# Altium schematic sheet Proot test board.SchDoc
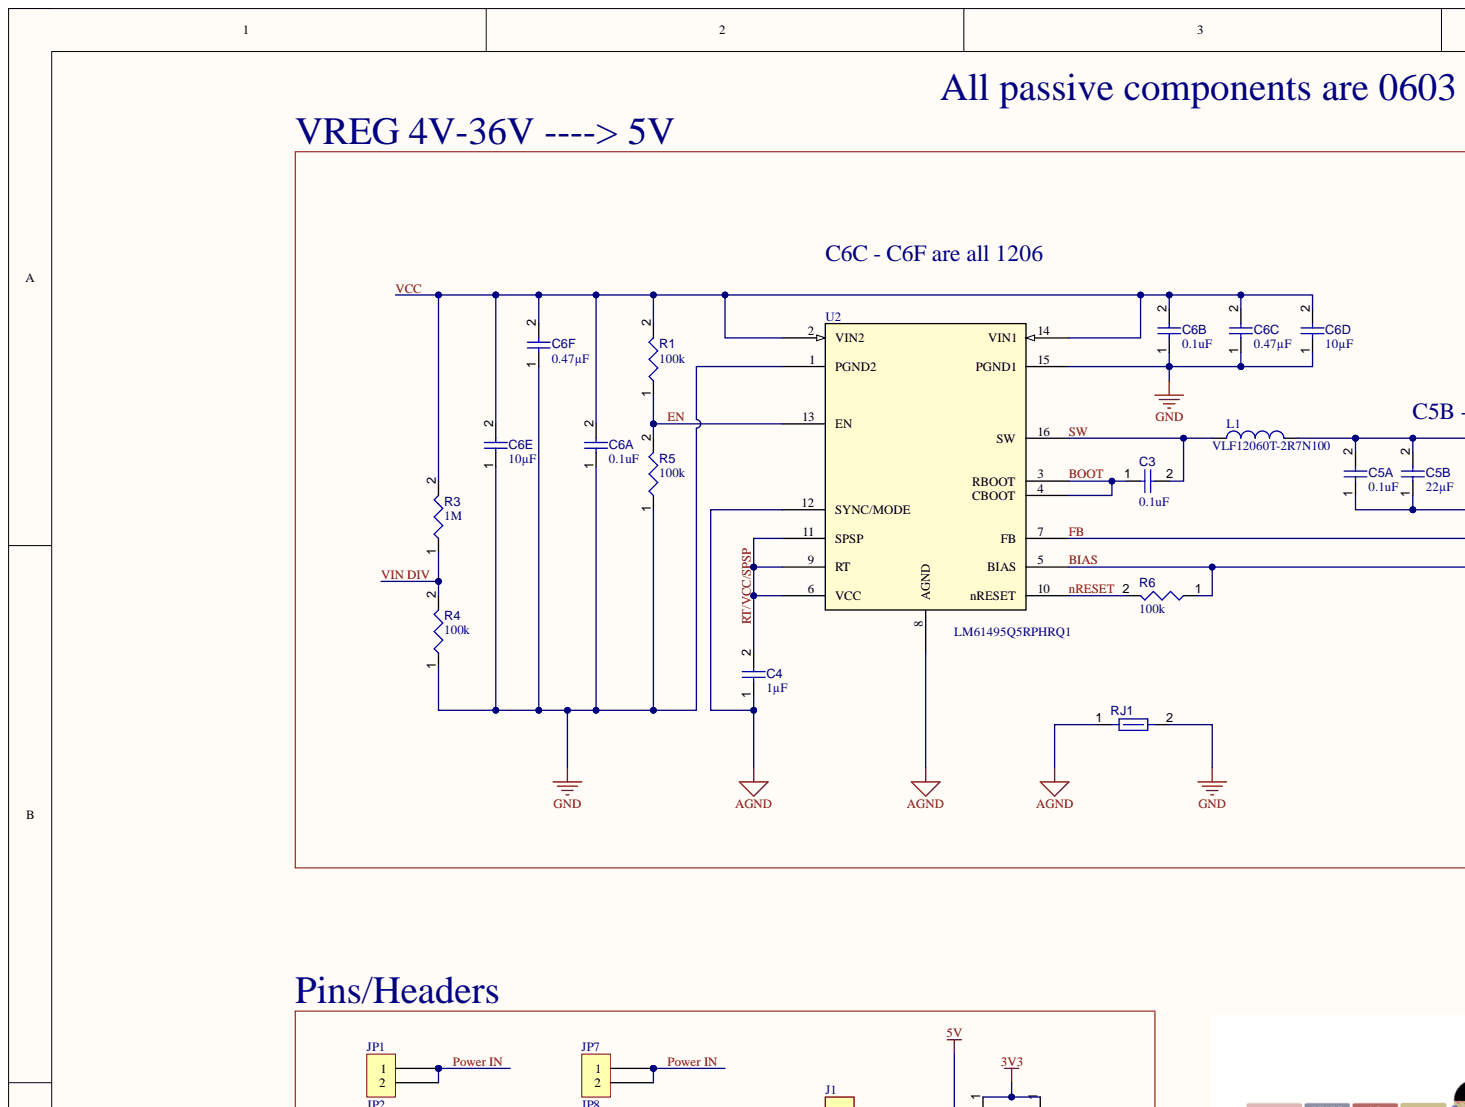
[diagram p0: Proot test board.SchDoc, top left]
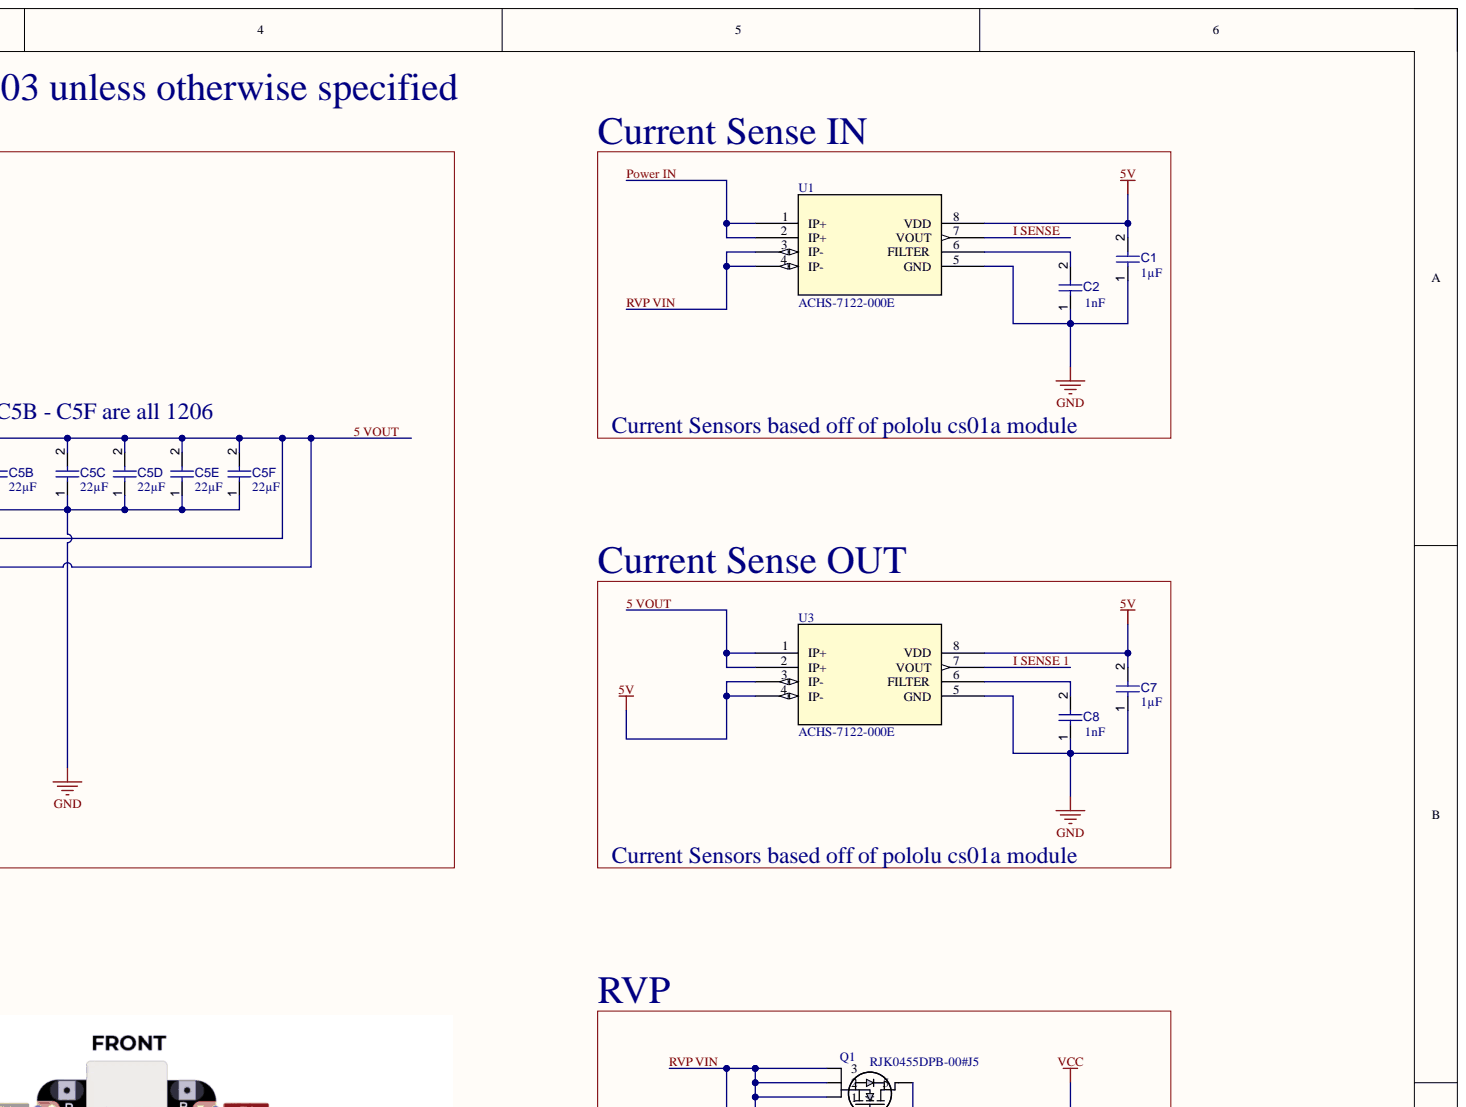
[diagram p1: Proot test board.SchDoc, top right]
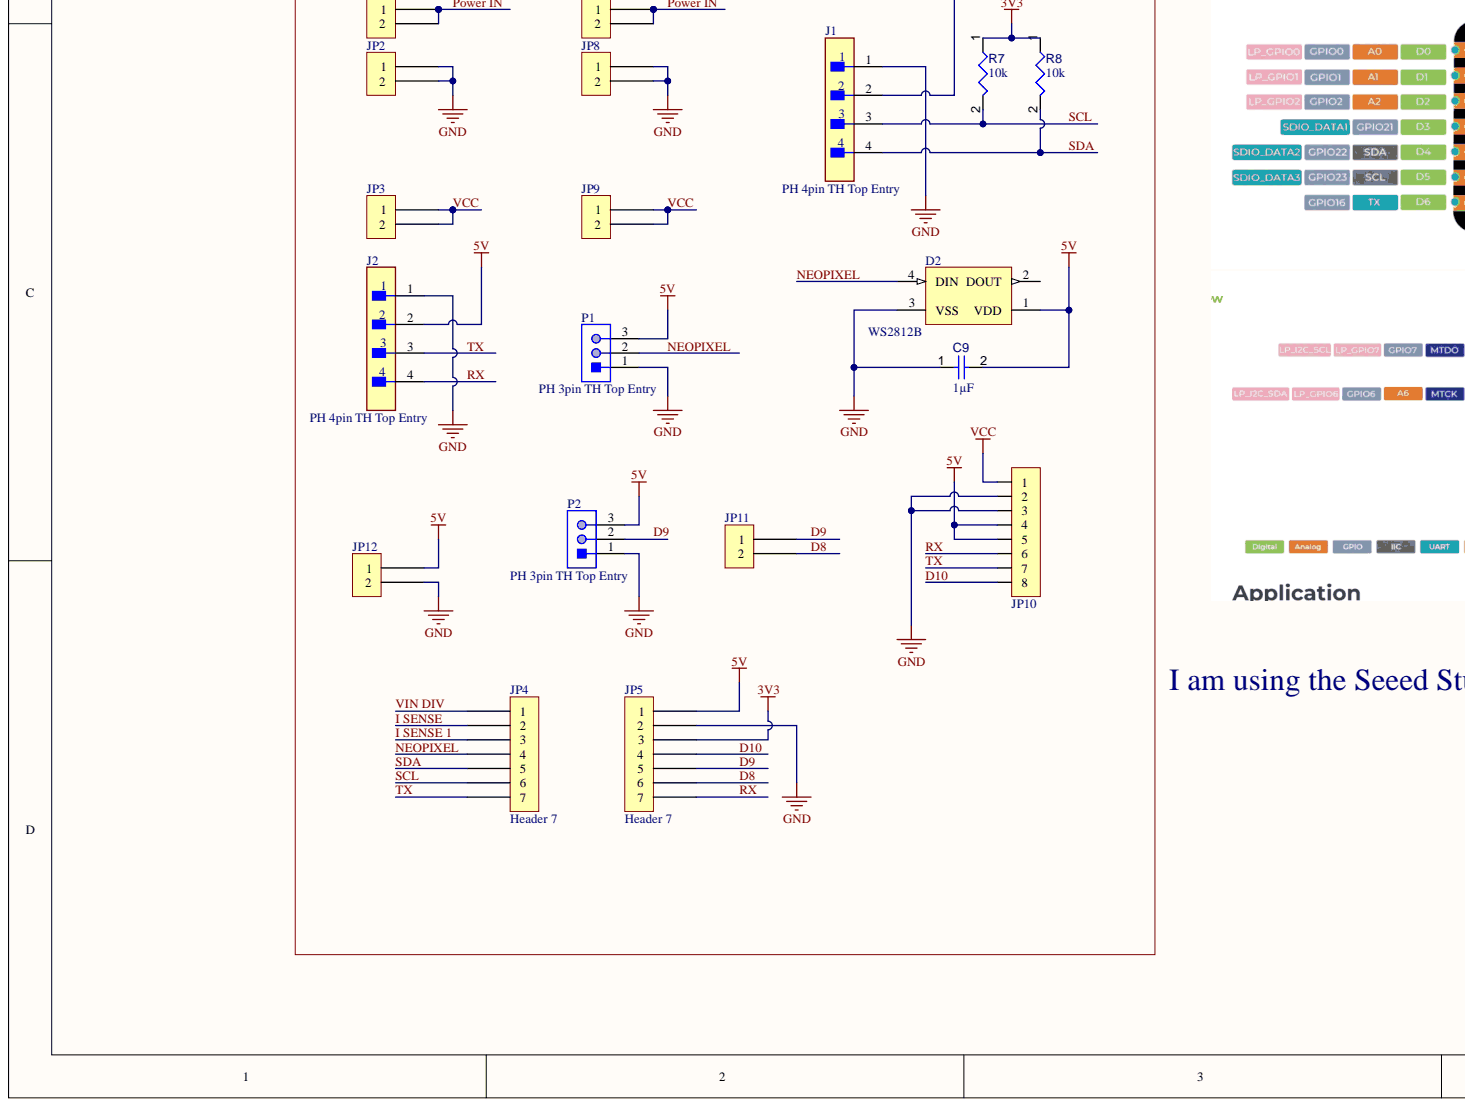
[diagram p2: Proot test board.SchDoc, bottom left]
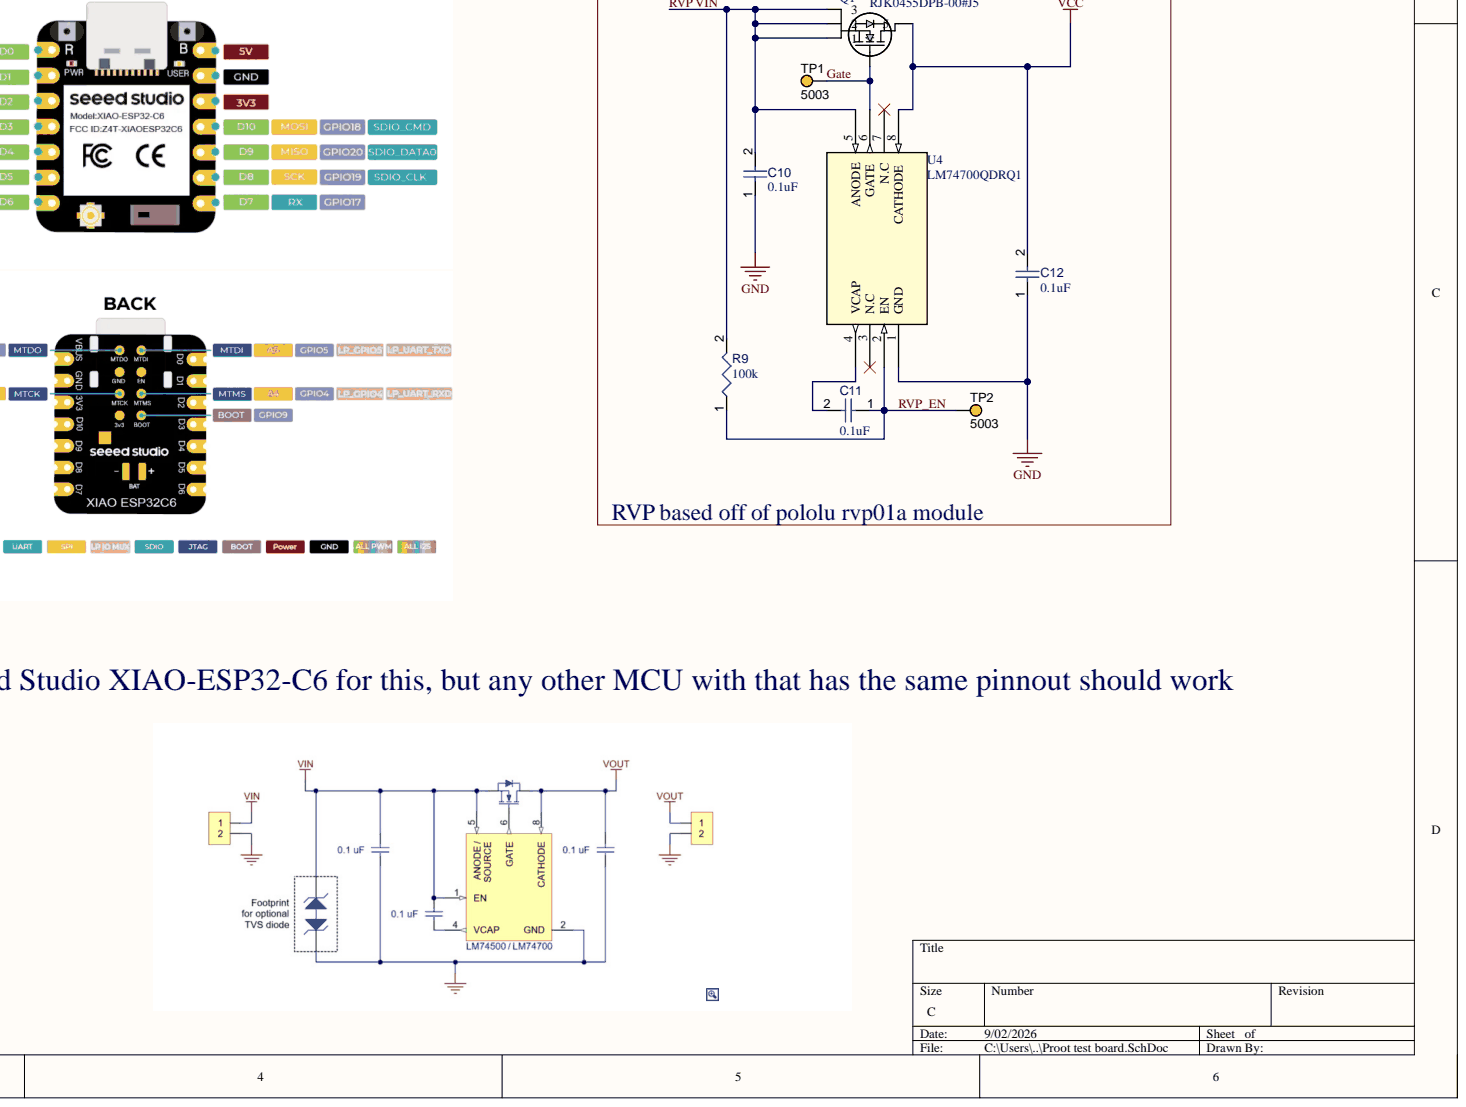
[diagram p3: Proot test board.SchDoc, bottom right]

## Parts
C1  value 1µF  [CAPC1608X94X35NL15T15]  (hidden comment: Capacitor 1 uF +/- 10% 16 V 0603)
C2  value 1nF  [CAPC1608X94X35NL15T15]  (hidden comment: Capacitor 1 uF +/- 10% 16 V 0603)
C3  value 0.1uF  [CAPC1608X94X35NL15T15]  (hidden comment: Capacitor 1 uF +/- 10% 16 V 0603)
C4  value 1µF  [CAPC1608X94X35NL15T15]  (hidden comment: Capacitor 1 uF +/- 10% 16 V 0603)
C5A  value 0.1uF  [CAPC1608X94X35NL15T15]  (hidden comment: Capacitor 1 uF +/- 10% 16 V 0603)
C5B  value 22µF  [CAPC3216X190X55LL30T25]  (hidden comment: Capacitor 100 uF +/- 20% 6.3 V 1206)
C5C  value 22µF  [CAPC3216X190X55LL30T25]  (hidden comment: Capacitor 100 uF +/- 20% 6.3 V 1206)
C5D  value 22µF  [CAPC3216X190X55LL30T25]  (hidden comment: Capacitor 100 uF +/- 20% 6.3 V 1206)
C5E  value 22µF  Case/Package: 1206  [CAPC3216X190X55LL30T25]  (hidden comment: Capacitor 100 uF +/- 20% 6.3 V 1206)
C5F  value 22µF  [CAPC3216X190X55LL30T25]  (hidden comment: Capacitor 100 uF +/- 20% 6.3 V 1206)
C6A  value 0.1uF  [CAPC1608X94X35NL15T15]  (hidden comment: Capacitor 1 uF +/- 10% 16 V 0603)
C6B  value 0.1uF  [CAPC1608X94X35NL15T15]  (hidden comment: Capacitor 1 uF +/- 10% 16 V 0603)
C6C  value 0.47µF  [CAPC3216X190X55LL30T25]  (hidden comment: Capacitor 100 uF +/- 20% 6.3 V 1206)
C6D  value 10µF  [CAPC3216X190X55LL30T25]  (hidden comment: Capacitor 100 uF +/- 20% 6.3 V 1206)
C6E  value 10µF  [CAPC3216X190X55LL30T25]  (hidden comment: Capacitor 100 uF +/- 20% 6.3 V 1206)
C6F  value 0.47µF  [CAPC3216X190X55LL30T25]  (hidden comment: Capacitor 100 uF +/- 20% 6.3 V 1206)
C7  value 1µF  [CAPC1608X94X35NL15T15]  (hidden comment: Capacitor 1 uF +/- 10% 16 V 0603)
C8  value 1nF  [CAPC1608X94X35NL15T15]  (hidden comment: Capacitor 1 uF +/- 10% 16 V 0603)
C9  value 1µF  [CAPC1608X94X35NL15T15]  (hidden comment: Capacitor 1 uF +/- 10% 16 V 0603)
C10  value 0.1uF  [CAPC1608X94X35NL15T15]  (hidden comment: Capacitor 1 uF +/- 10% 16 V 0603)
C11  value 0.1uF  [CAPC1608X94X35NL15T15]  (hidden comment: Capacitor 1 uF +/- 10% 16 V 0603)
C12  value 0.1uF  [CAPC1608X94X35NL15T15]  (hidden comment: Capacitor 1 uF +/- 10% 16 V 0603)
D2  WS2812B  [ADAI-WS2812B_V]
J1  PH 4pin TH Top Entry  [FP-B4B-PH-KL_LF_SN-MFG]
J2  PH 4pin TH Top Entry  [FP-B4B-PH-KL_LF_SN-MFG]
JP1  Header 2  [Header 2-Footprint-1]  (value not drawn)
JP2  Header 2  [Header 2-Footprint-1]  (value not drawn)
JP3  Header 2  [Header 2-Footprint-1]  (value not drawn)
JP4  Header 7  [New footprint 1]
JP5  Header 7  [New footprint 1]
JP7  Header 2  [Header 2-Footprint-1]  (value not drawn)
JP8  Header 2  [Header 2-Footprint-1]  (value not drawn)
JP9  Header 2  [Header 2-Footprint-1]  (value not drawn)
JP10  Header 8  [HDR1X8]  (value not drawn)
JP11  Header 2  [Header 2-Footprint-1]  (value not drawn)
JP12  Header 2  [Header 2-Footprint-1]  (value not drawn)
L1  VLF12060T-2R7N100  [INDP118122X600N]
P1  PH 3pin TH Top Entry  [JST-B3B-PH-K-S-3_V]
P2  PH 3pin TH Top Entry  [JST-B3B-PH-K-S-3_V]
Q1  RJK0455DPB-00#J5  [LFPAK_REN]
R1  value 100k  [RESC1608X55X25ML10T15]  (hidden comment: Resistor 100k +/-1% 0603 100 mW)
R3  value 1M  [RESC1608X55X25ML10T15]  (hidden comment: Resistor 100k +/-1% 0603 100 mW)
R4  value 100k  [RESC1608X55X25ML10T15]  (hidden comment: Resistor 100k +/-1% 0603 100 mW)
R5  value 100k  [RESC1608X55X25ML10T15]  (hidden comment: Resistor 100k +/-1% 0603 100 mW)
R6  value 100k  [RESC1608X55X25ML10T15]  (hidden comment: Resistor 100k +/-1% 0603 100 mW)
R7  value 10k  [RESC1608X55X25ML10T15]  (hidden comment: Resistor 100k +/-1% 0603 100 mW)
R8  value 10k  [RESC1608X55X25ML10T15]  (hidden comment: Resistor 100k +/-1% 0603 100 mW)
R9  value 100k  [RESC1608X55X25ML10T15]  (hidden comment: Resistor 100k +/-1% 0603 100 mW)
RJ1  Net Tie 10x8mil  [New footprint 1]  (value not drawn)
TP1  5003  [KSTN-5003]
TP2  5003  [KSTN-5003]
U1  ACHS-7122-000E  [SO8_ACHS-7122_BRA]
U2  LM61495Q5RPHRQ1  [VQFN-HR16_RPH_TEX]
U3  ACHS-7122-000E  [SO8_ACHS-7122_BRA]
U4  LM74700QDRQ1  [SOIC8_D_TEX]

## Nets
3V3: JP5.3 R7.1 R8.1
5V: C1.2 C7.2 C9.2 D2.1(VDD) J1.2 J2.2 JP5.1 JP10.4 JP10.5 JP12.1 P1.3 P2.3 U1.8(VDD) U3.3(IP-) U3.4(IP-) U3.8(VDD)
AGND: C4.1 RJ1.1 U2.8(AGND) U2.12(SYNC/MODE)
GND: C1.1 C2.1 C5A.1 C5B.1 C5C.1 C5D.1 C5E.1 C5F.1 C6A.1 C6B.1 C6C.1 C6D.1 C6E.1 C6F.1 C7.1 C8.1 C9.1 C10.1 C12.1 D2.3(VSS) J1.1 J2.1 JP2.1 JP2.2 JP5.2 JP8.1 JP8.2 JP10.2 JP10.3 JP12.2 P1.1 P2.1 R4.1 R5.1 RJ1.2 U1.5(GND) U2.1(PGND2) U2.15(PGND1) U3.5(GND) U4.1(GND)
5 VOUT: C5A.2 C5B.2 C5C.2 C5D.2 C5E.2 C5F.2 L1.2 R6.1 U2.5(BIAS) U2.7(FB) U3.1(IP+) U3.2(IP+)
BOOT: C3.1 U2.3(RBOOT) U2.4(CBOOT)
D8: JP5.6 JP11.2
D9: JP5.5 JP11.1 P2.2
D10: JP5.4 JP10.8
EN: R1.1 R5.2 U2.13(EN)
Gate: Q1.4 TP1.1 U4.6(GATE)
I SENSE: JP4.2 U1.7(VOUT)
I SENSE 1: JP4.3 U3.7(VOUT)
NEOPIXEL: D2.4(DIN) JP4.4 P1.2
nRESET: R6.2 U2.10(nRESET)
Power IN: JP1.1 JP1.2 JP7.1 JP7.2 U1.1(IP+) U1.2(IP+)
RT/VCC/SPSP: C4.2 U2.6(VCC) U2.9(RT) U2.11(SPSP)
RVP VIN: C10.2 Q1.1 Q1.2 Q1.3 R9.2 U1.3(IP-) U1.4(IP-) U4.5(ANODE)
RVP_EN: C11.1 R9.1 TP2.1 U4.2(EN)
RX: J2.4 JP5.7 JP10.6
SCL: J1.3 JP4.6 R7.2
SDA: J1.4 JP4.5 R8.2
SW: C3.2 L1.1 U2.16(SW)
TX: J2.3 JP4.7 JP10.7
VCC: C6A.2 C6B.2 C6C.2 C6D.2 C6E.2 C6F.2 C12.2 JP3.1 JP3.2 JP9.1 JP9.2 JP10.1 Q1.5 R1.2 R3.2 U2.2(VIN2) U2.14(VIN1) U4.8(CATHODE)
VIN DIV: JP4.1 R3.1 R4.2
NetC2_2: C2.2 U1.6(FILTER)
NetC8_2: C8.2 U3.6(FILTER)
NetC11_2: C11.2 U4.4(VCAP)

Not connected: D2 2(DOUT); U4 3(N.C) 7(N.C)

## Library data of the parts
C1-C4 C5A C6A C6B C7-C12 = CMP-001-00003-6: Chip Capacitor, 1 uF, +/- 10%, 16 V, 0603 (1608 Metric); Capacitor Type: Ceramic; Voltage Rating: 16V; Case/Package: 0603; Component Kind: Standard; Max Operating Temperature: 125°C; Min Operating Temperature: -55°C; Mounting Technology: SM; Pins: 2; RoHS Compliant: True; Tolerance: 10%; Library Name: notthepotato
C5B C5C C5D C5E C5F C6C C6D C6E C6F = CMP-001-00019-6: Chip Capacitor, 100 uF, +/- 20%, 6.3 V, 1206 (3216 Metric); Capacitor Type: Ceramic; Voltage Rating: 6.3V; Component Kind: Standard; Max Operating Temperature: 85°C; Min Operating Temperature: -55°C; Mounting Technology: SM; Pins: 2; RoHS Compliant: True; Tolerance: 20%; Library Name: notthepotato
  C5B C5C C5D C5F C6C C6D C6E C6F: Case/Package: 1206
D2 = CMP-007-00035-2: Intelligent Control LED Integrated Light Source, 3.5 to 5.3 V, -25 to 80 degC, 4-Pin SMD, RoHS, Bulk; Manufacturer: Adafruit Industries; Case/Package: WS2812B; Component Kind: Standard; Datasheet URL: https://cdn-shop.adafruit.com/datasheets/WS2812B.pdf; Datasheet Version: May-13; Manufacturer URL: https://www.adafruit.com/; Mounting Technology: SM; Package Description: 4-Pin Surface Mount Device, Body 5 x 5 mm, Pitch 3.3 mm; Package Reference: WS2812B; RoHS Compliant: False; Library Name: notthepotato
J1 J2 = CMP-002-00079-1: CONN HEADER VERT 4POS 2MM / Connector Header Through Hole 4 position 0.079" (2.00mm); Gender: Male; Voltage Rating: 100V; Component Kind: Standard; Max Operating Temperature: 85°C; Min Operating Temperature: -25°C; Mounting Technology: Through Hole; Library Name: notthepotato
JP1-JP3 JP7-JP9 JP11 JP12 = CMP-002-00072-2: Header Pin 2x1; Component Kind: Standard; Library Name: notthepotato
JP4 JP5 = CMP-002-00073-1: 1x7 Header; Component Kind: Standard; Library Name: notthepotato
JP10 = Header 8: Header, 8-Pin; Manufacturer: Generic Components; Class I: Connector; Component Kind: Standard; Library Name: connector.SchLib; Revision: July-2002: Re-released for DXP Platform.; Publisher: Altium Limited
L1 = CMP-006-00021-1: VLF12060T-2R7N100 2.7uH 30% 10A, 12A saturation; Component Kind: Standard; Library Name: notthepotato
P1 P2 = CMP-002-00080-1: Connector Header, PH Series, 3 Position, 2.00mm, Through Hole, Press-Fit, Solder, Natural; Connector Type: Male Pin; Gender: Male; Max Current Rating: 2A; Voltage Rating: 100V; Component Kind: Standard; Max Operating Temperature: 85°C; Min Operating Temperature: -25°C; Mounting Technology: Through Hole; Pins: 3; Library Name: notthepotato
Q1 = RJK0455DPB-00J5: No Description Available; Manufacturer_Name: Renesas Electronics; Component Kind: Standard; Library Name: RJK0455DPB-00J5.SchLib; Manufacturer_Part_Number: RJK0455DPB-00#J5; Copyright: Copyright (C) 2026 Ultra Librarian. All rights reserved.
R1 R3-R9 = CMP-009-00023-5: Chip Resistor, 100 KOhm, +/- 1%, 0.1 W, -55 to 155 degC, 0603 (1608 Metric); Power: 100mW; Voltage Rating: 50V; Case/Package: 0603; Component Kind: Standard; Max Operating Temperature: 155°C; Min Operating Temperature: -55°C; Mounting Technology: SM; Pins: 2; RoHS Compliant: True; Tolerance: 1%; Library Name: notthepotato
RJ1 = CMP-009-00116-2: Component Kind: Net Tie; Description: Net Tie 10x8mil; Max Operating Temperature: 155°C; Min Operating Temperature: -55°C; Pins: 2; Library Name: notthepotato
TP1 TP2 = CMP-002-00037-2: Test Point, Orange, Height 4.6 mm, Tail Length 3 mm, 1-Pin THD, RoHS; Manufacturer: Keystone; Case/Package: 5003; Colour: Orange; Component Kind: Standard; Connector Type: TestPoint; Contact Material: Bronze; Contact Plating: Tin; Datasheet URL: http://keyelco.com/userAssets/file/M65p56.pdf; Datasheet Version: Jun-15; Diameter: 2.54mm; Manufacturer URL: http://www.keyelco.com/; Mounting Technology: TH; Package Description: 1-Pin Through Hole Device, Dia 2.54 mm; Package Quantity: 10; Package Reference: 5003; Packaging: Bulk; Pins: 1; RoHS Compliant: True; Library Name: notthepotato
U1 U3 = ACHS-7122-000E: Description; Manufacturer_Name: Broadcom; Component Kind: Standard; Library Name: ACHS-7122-000E.IntLib; Manufacturer_Part_Number: ACHS-7122-000E; RefDes: RefDes; Type: Type; Copyright: Copyright (C) 2025 Ultra Librarian. All rights reserved.
U2 = LM61495Q5RPHRQ1: 10-A automotive buck converter optimized for power density and low EMI 16-VQFN-HR -40 to 150; Manufacturer_Name: Texas Instruments; Component Kind: Standard; Library Name: LM61495Q5RPHRQ1.IntLib; Manufacturer_Part_Number: LM61495Q5RPHRQ1; RefDes: RefDes; Type: Type; Copyright: Copyright (C) 2025 Ultra Librarian. All rights reserved.
U4 = LM74700QDRQ1: Automotive, 3.2-V to 70-V, 80-ÂµA reverse battery protection ideal diode controller 8-SOIC -40 to 125; Manufacturer_Name: Texas Instruments; Component Kind: Standard; Library Name: LM74700QDRQ1.SchLib; Manufacturer_Part_Number: LM74700QDRQ1; RefDes: RefDes; Type: Type; Copyright: Copyright (C) 2025 Ultra Librarian. All rights reserved.
